# Altium schematic sheet Motor.SchDoc
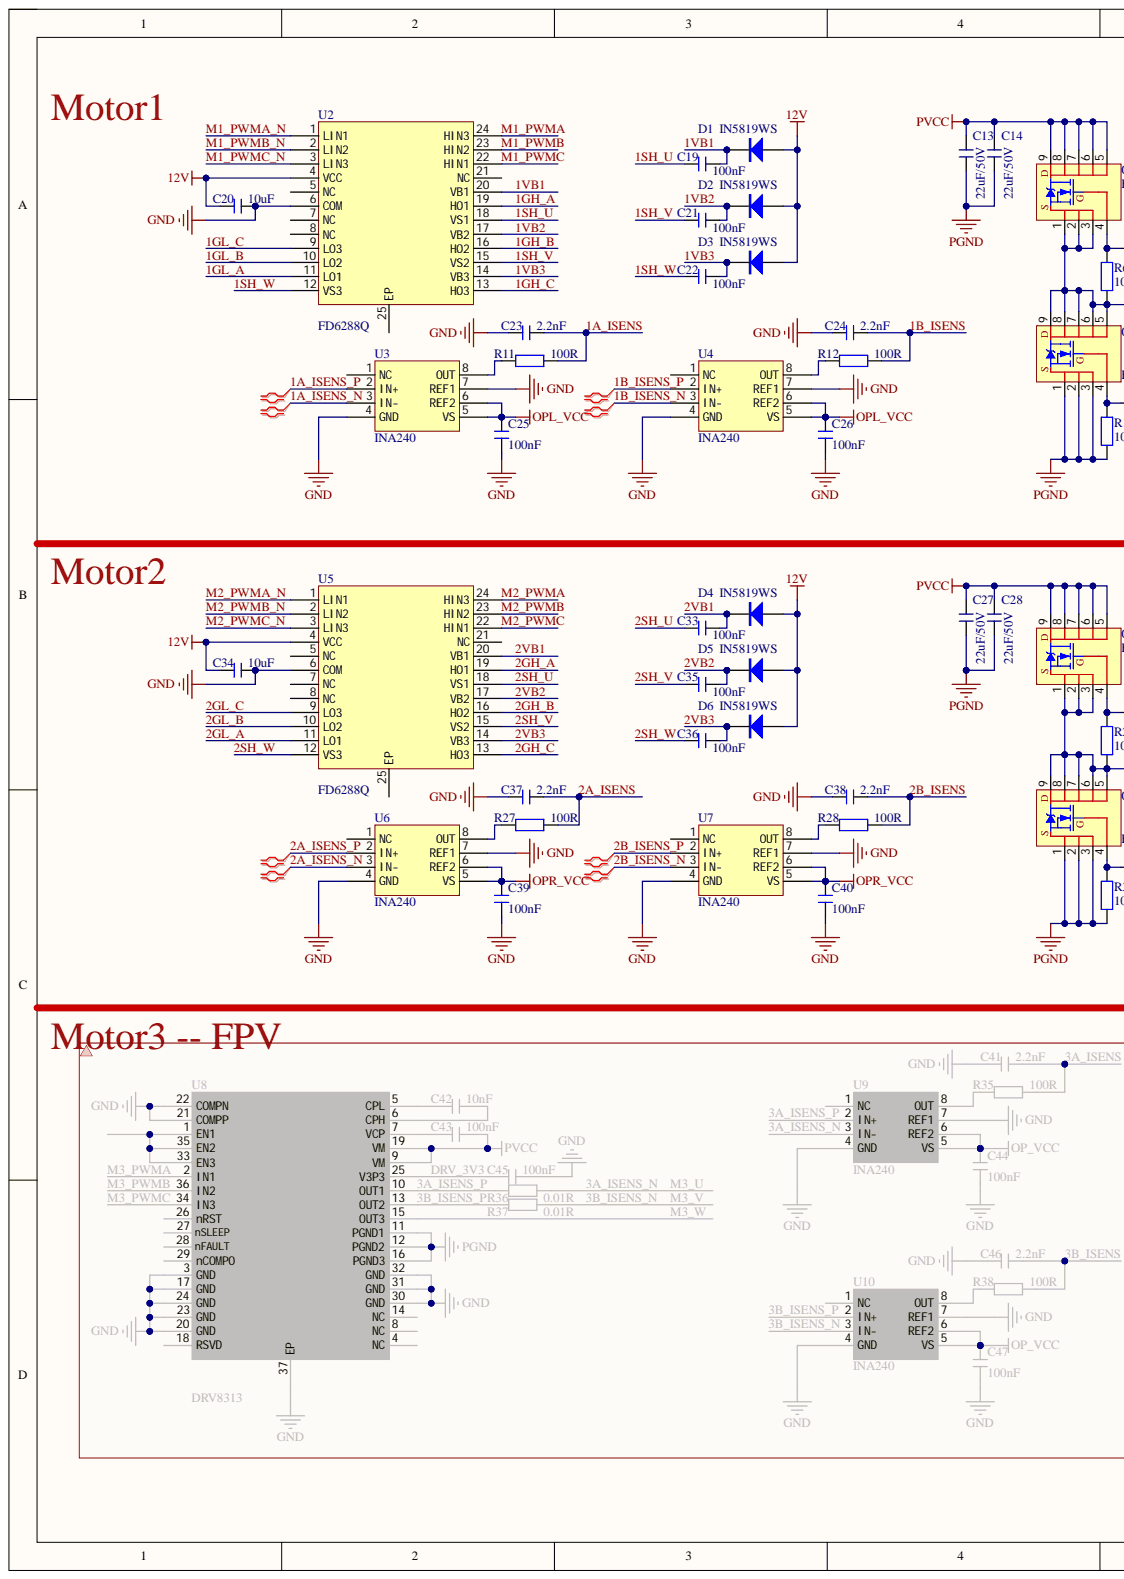
[diagram p0: Motor.SchDoc, left]
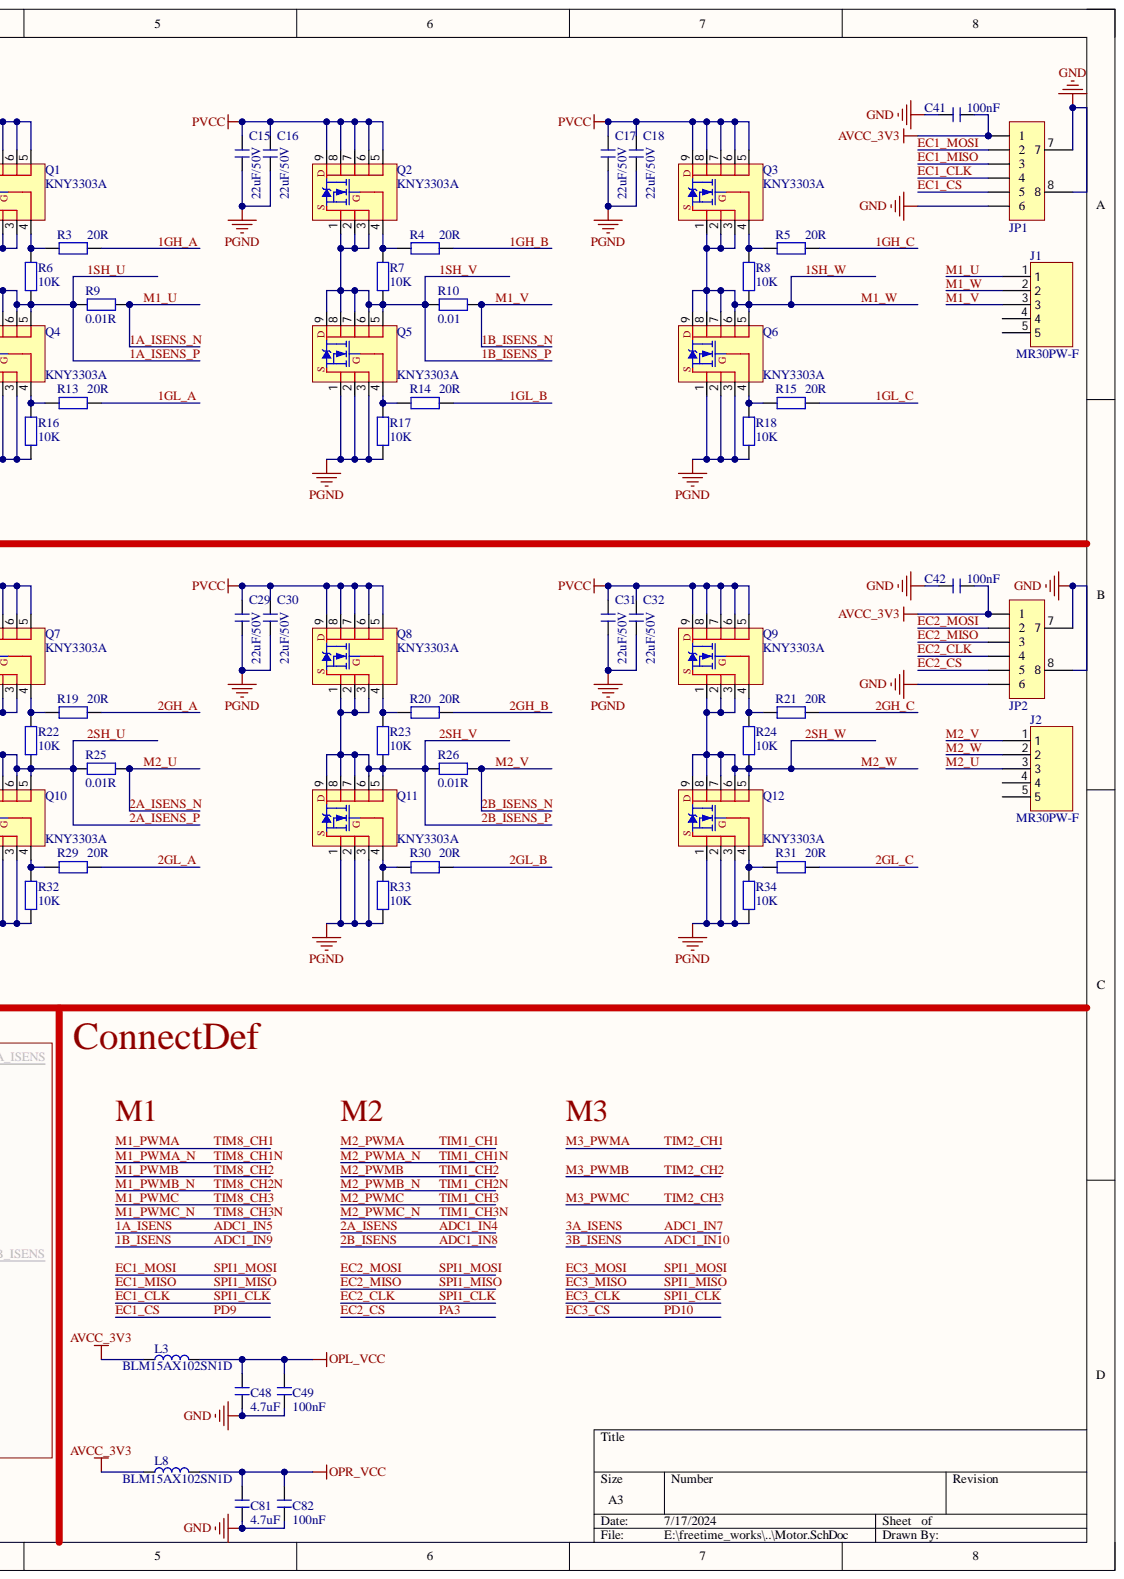
[diagram p1: Motor.SchDoc, right]

## Parts
C13  22uF/50V  [C 1206_L]
C14  22uF/50V  [C 1206_L]
C15  22uF/50V  [C 1206_L]
C16  22uF/50V  [C 1206_L]
C17  22uF/50V  [C 1206_L]
C18  22uF/50V  [C 1206_L]
C19  100nF  [C 0402_L]
C20  10uF  [C 0603_L]
C21  100nF  [C 0402_L]
C22  100nF  [C 0402_L]
C23  2.2nF  [C 0402_L]
C24  2.2nF  [C 0402_L]
C25  100nF  [C 0402_L]
C26  100nF  [C 0402_L]
C27  22uF/50V  [C 1206_L]
C28  22uF/50V  [C 1206_L]
C29  22uF/50V  [C 1206_L]
C30  22uF/50V  [C 1206_L]
C31  22uF/50V  [C 1206_L]
C32  22uF/50V  [C 1206_L]
C33  100nF  [C 0402_L]
C34  10uF  [C 0603_L]
C35  100nF  [C 0402_L]
C36  100nF  [C 0402_L]
C37  2.2nF  [C 0402_L]
C38  2.2nF  [C 0402_L]
C39  100nF  [C 0402_L]
C40  100nF  [C 0402_L]
C41  100nF  [C 0402_L]
C42  100nF  [C 0402_L]
C48  4.7uF  [C 0402_L]
C49  100nF  [C 0402_L]
C81  4.7uF  [C 0402_L]
C82  100nF  [C 0402_L]
D1  IN5819WS  [SOD-323]
D2  IN5819WS  [SOD-323]
D3  IN5819WS  [SOD-323]
D4  IN5819WS  [SOD-323]
D5  IN5819WS  [SOD-323]
D6  IN5819WS  [SOD-323]
J1  MR30PW-F  [MR30PW_F]
J2  MR30PW-F  [MR30PW_F]
JP1  HDR-1X6  [GH1.25-WS-6P]  (value not drawn)
JP2  HDR-1X6  [GH1.25-WS-6P]  (value not drawn)
L3  BLM15AX102SN1D  [L 0402]
L8  BLM15AX102SN1D  [L 0402]
Q1  KNY3303A  [PDFN-8(5.8x4.9)]
Q2  KNY3303A  [PDFN-8(5.8x4.9)]
Q3  KNY3303A  [PDFN-8(5.8x4.9)]
Q4  KNY3303A  [PDFN-8(5.8x4.9)]
Q5  KNY3303A  [PDFN-8(5.8x4.9)]
Q6  KNY3303A  [PDFN-8(5.8x4.9)]
Q7  KNY3303A  [PDFN-8(5.8x4.9)]
Q8  KNY3303A  [PDFN-8(5.8x4.9)]
Q9  KNY3303A  [PDFN-8(5.8x4.9)]
Q10  KNY3303A  [PDFN-8(5.8x4.9)]
Q11  KNY3303A  [PDFN-8(5.8x4.9)]
Q12  KNY3303A  [PDFN-8(5.8x4.9)]
R3  20R  [R 0402_L]
R4  20R  [R 0402_L]
R5  20R  [R 0402_L]
R6  10K  [R 0402_L]
R7  10K  [R 0402_L]
R8  10K  [R 0402_L]
R9  0.01R  [R 2512_L]
R10  0.01  [R 2512_L]
R11  100R  [R 0402_L]
R12  100R  [R 0402_L]
R13  20R  [R 0402_L]
R14  20R  [R 0402_L]
R15  20R  [R 0402_L]
R16  10K  [R 0402_L]
R17  10K  [R 0402_L]
R18  10K  [R 0402_L]
R19  20R  [R 0402_L]
R20  20R  [R 0402_L]
R21  20R  [R 0402_L]
R22  10K  [R 0402_L]
R23  10K  [R 0402_L]
R24  10K  [R 0402_L]
R25  0.01R  [R 2512_L]
R26  0.01R  [R 2512_L]
R27  100R  [R 0402_L]
R28  100R  [R 0402_L]
R29  20R  [R 0402_L]
R30  20R  [R 0402_L]
R31  20R  [R 0402_L]
R32  10K  [R 0402_L]
R33  10K  [R 0402_L]
R34  10K  [R 0402_L]
U2  FD6288Q  [QFN24 4x4_L]
U3  INA240  [SSOP8_L]
U4  INA240  [SSOP8_L]
U5  FD6288Q  [QFN24 4x4_L]
U6  INA240  [SSOP8_L]
U7  INA240  [SSOP8_L]

## Nets
12V: C20.1 C34.1 D1.2 D2.2 D3.2 D4.2 D5.2 D6.2 U2.4(VCC) U5.4(VCC)
AVCC_3V3: C41.2 C42.2 JP1.1 JP2.1 L3.1 L8.1
GND: C20.2 C23.1 C24.1 C25.1 C26.1 C34.2 C37.1 C38.1 C39.1 C40.1 C41.1 C42.1 C48.1 C49.1 C81.1 C82.1 JP1.6 JP1.7 JP1.8 JP2.6 JP2.7 JP2.8 U2.6(COM) U3.4(GND) U3.7(REF1) U4.4(GND) U4.7(REF1) U5.6(COM) U6.4(GND) U6.7(REF1) U7.4(GND) U7.7(REF1)
OPL_VCC: C25.2 C26.2 C48.2 C49.2 L3.2 U3.5(VS) U3.6(REF2) U4.5(VS) U4.6(REF2)
OPR_VCC: C39.2 C40.2 C81.2 C82.2 L8.2 U6.5(VS) U6.6(REF2) U7.5(VS) U7.6(REF2)
PGND: C13.1 C14.1 C15.1 C16.1 C17.1 C18.1 C27.1 C28.1 C29.1 C30.1 C31.1 C32.1 Q4.1 Q4.2(G) Q4.3 Q5.1 Q5.2 Q5.3 Q6.1 Q6.2(G) Q6.3 Q10.1 Q10.2(G) Q10.3 Q11.1 Q11.2 Q11.3 Q12.1 Q12.2(G) Q12.3 R16.1 R17.1 R18.1 R32.1 R33.1 R34.1
PVCC: C13.2 C14.2 C15.2 C16.2 C17.2 C18.2 C27.2 C28.2 C29.2 C30.2 C31.2 C32.2 Q1.5 Q1.6 Q1.7 Q1.8 Q1.9 Q2.5 Q2.6 Q2.7 Q2.8 Q2.9 Q3.5 Q3.6 Q3.7 Q3.8 Q3.9(D) Q7.5 Q7.6 Q7.7 Q7.8 Q7.9 Q8.5 Q8.6 Q8.7 Q8.8 Q8.9 Q9.5 Q9.6 Q9.7 Q9.8 Q9.9(D)
1A_ISENS: C23.2 R11.2
1A_ISENS_N: J1.1 R9.2 U3.3(IN-)
1A_ISENS_P: C19.1 Q1.1 Q1.2(G) Q1.3 Q4.5 Q4.6 Q4.7 Q4.8 Q4.9 R6.1 R9.1 U2.18(VS1) U3.2(IN+)
1B_ISENS: C24.2 R12.2
1B_ISENS_N: J1.3 R10.2 U4.3(IN-)
1B_ISENS_P: C21.1 Q2.1 Q2.2 Q2.3 Q5.5 Q5.6 Q5.7 Q5.8 Q5.9 R7.1 R10.1 U2.15(VS2) U4.2(IN+)
1GH_A: R3.2 U2.19(HO1)
1GH_B: R4.2 U2.16(HO2)
1GH_C: R5.2 U2.13(HO3)
1GL_A: R13.2 U2.11(LO1)
1GL_B: R14.2 U2.10(LO2)
1GL_C: R15.2 U2.9(LO3)
1SH_W: C22.1 J1.2 Q3.1 Q3.2(G) Q3.3 Q6.5 Q6.6 Q6.7 Q6.8 Q6.9(D) R8.1 U2.12(VS3)
1VB1: C19.2 D1.1 U2.20(VB1)
1VB2: C21.2 D2.1 U2.17(VB2)
1VB3: C22.2 D3.1 U2.14(VB3)
2A_ISENS: C37.2 R27.2
2A_ISENS_N: J2.3 R25.2 U6.3(IN-)
2A_ISENS_P: C33.1 Q7.1 Q7.2(G) Q7.3 Q10.5 Q10.6 Q10.7 Q10.8 Q10.9 R22.1 R25.1 U5.18(VS1) U6.2(IN+)
2B_ISENS: C38.2 R28.2
2B_ISENS_N: J2.1 R26.2 U7.3(IN-)
2B_ISENS_P: C35.1 Q8.1 Q8.2 Q8.3 Q11.5 Q11.6 Q11.7 Q11.8 Q11.9 R23.1 R26.1 U5.15(VS2) U7.2(IN+)
2GH_A: R19.2 U5.19(HO1)
2GH_B: R20.2 U5.16(HO2)
2GH_C: R21.2 U5.13(HO3)
2GL_A: R29.2 U5.11(LO1)
2GL_B: R30.2 U5.10(LO2)
2GL_C: R31.2 U5.9(LO3)
2SH_W: C36.1 J2.2 Q9.1 Q9.2(G) Q9.3 Q12.5 Q12.6 Q12.7 Q12.8 Q12.9(D) R24.1 U5.12(VS3)
2VB1: C33.2 D4.1 U5.20(VB1)
2VB2: C35.2 D5.1 U5.17(VB2)
2VB3: C36.2 D6.1 U5.14(VB3)
EC1_CLK: JP1.4 JP2.4
EC1_CS: JP1.5
EC1_MISO: JP1.3 JP2.3
EC1_MOSI: JP1.2 JP2.2
EC2_CS: JP2.5
M1_PWMA: U2.24(HIN3)
M1_PWMA_N: U2.1(LIN1)
M1_PWMB: U2.23(HIN2)
M1_PWMB_N: U2.2(LIN2)
M1_PWMC: U2.22(HIN1)
M1_PWMC_N: U2.3(LIN3)
M2_PWMA: U5.24(HIN3)
M2_PWMA_N: U5.1(LIN1)
M2_PWMB: U5.23(HIN2)
M2_PWMB_N: U5.2(LIN2)
M2_PWMC: U5.22(HIN1)
M2_PWMC_N: U5.3(LIN3)
NetQ1_4: Q1.4 R3.1 R6.2
NetQ2_4: Q2.4 R4.1 R7.2
NetQ3_4: Q3.4 R5.1 R8.2
NetQ4_4: Q4.4 R13.1 R16.2
NetQ5_4: Q5.4 R14.1 R17.2
NetQ6_4: Q6.4 R15.1 R18.2
NetQ7_4: Q7.4 R19.1 R22.2
NetQ8_4: Q8.4 R20.1 R23.2
NetQ9_4: Q9.4 R21.1 R24.2
NetQ10_4: Q10.4 R29.1 R32.2
NetQ11_4: Q11.4 R30.1 R33.2
NetQ12_4: Q12.4 R31.1 R34.2
NetR11_1: R11.1 U3.8(OUT)
NetR12_1: R12.1 U4.8(OUT)
NetR27_1: R27.1 U6.8(OUT)
NetR28_1: R28.1 U7.8(OUT)
3A_ISENS:
3B_ISENS:
EC3_CS:
M3_PWMA:
M3_PWMB:
M3_PWMC:

Not connected: J1 4 5; J2 4 5; U2 5(NC) 7(NC) 8(NC) 21(NC) 25(EP); U3 1(NC); U4 1(NC); U5 5(NC) 7(NC) 8(NC) 21(NC) 25(EP); U6 1(NC); U7 1(NC)

## Library data of the parts
C13-C42 C48 C49 C81 C82 = C: 无极性贴片电容; 版权归属: 源创客; BOM名称: 无极性贴片电容; Component Kind: Standard; Library Name: 3.1   - 电阻-电容-电感.SchLib; 学习资源: www.ooocke.com
D1-D6 = 1N5819: 肖特基二极管; 属性: 40V/2A; 版权归属: 源创客; BOM名称: 肖特基二极管; Component Kind: Standard; Library Name: 8.1   - 二极管-整流桥.SchLib; 学习资源: www.ooocke.com
J1 J2 = MR30PW-F: 航模接口; Component Kind: Standard; Library Name: 4.13 - 接插件-未分类.SchLib
JP1 JP2 = Header 6: 6P接插件; 版权归属: 源创客; BOM名称: 6P接插件; Component Kind: Standard; Library Name: 4.2   - 接插件-脚距1.25.SchLib; 学习资源: www.ooocke.com
L3 L8 = L: 小功率贴片电感; 版权归属: 源创客; BOM名称: 小功率贴片电感; Component Kind: Standard; Library Name: 3.1   - 电阻-电容-电感.SchLib; 学习资源: www.ooocke.com
Q1-Q12 = KNY3303A: N沟道MOS管  PDFN-8(5.8x4.9); Component Kind: Standard; Library Name: 6.1   - 场效应管-可控硅.SchLib
R3-R34 = R: 贴片电阻; 版权归属: 源创客; BOM名称: 贴片电阻; Component Kind: Standard; Library Name: 3.1   - 电阻-电容-电感.SchLib; 学习资源: www.ooocke.com
U2 U5 = FD6288Q: 栅极驱动IC; Component Kind: Standard; Library Name: 1.1   - IC芯片.SchLib
U3 U4 U6 U7 = INA240: PWM抑制电流检测芯片; Component Kind: Standard; Library Name: 1.1   - IC芯片.SchLib
